# Altium schematic sheet Core.SchDoc(Core)
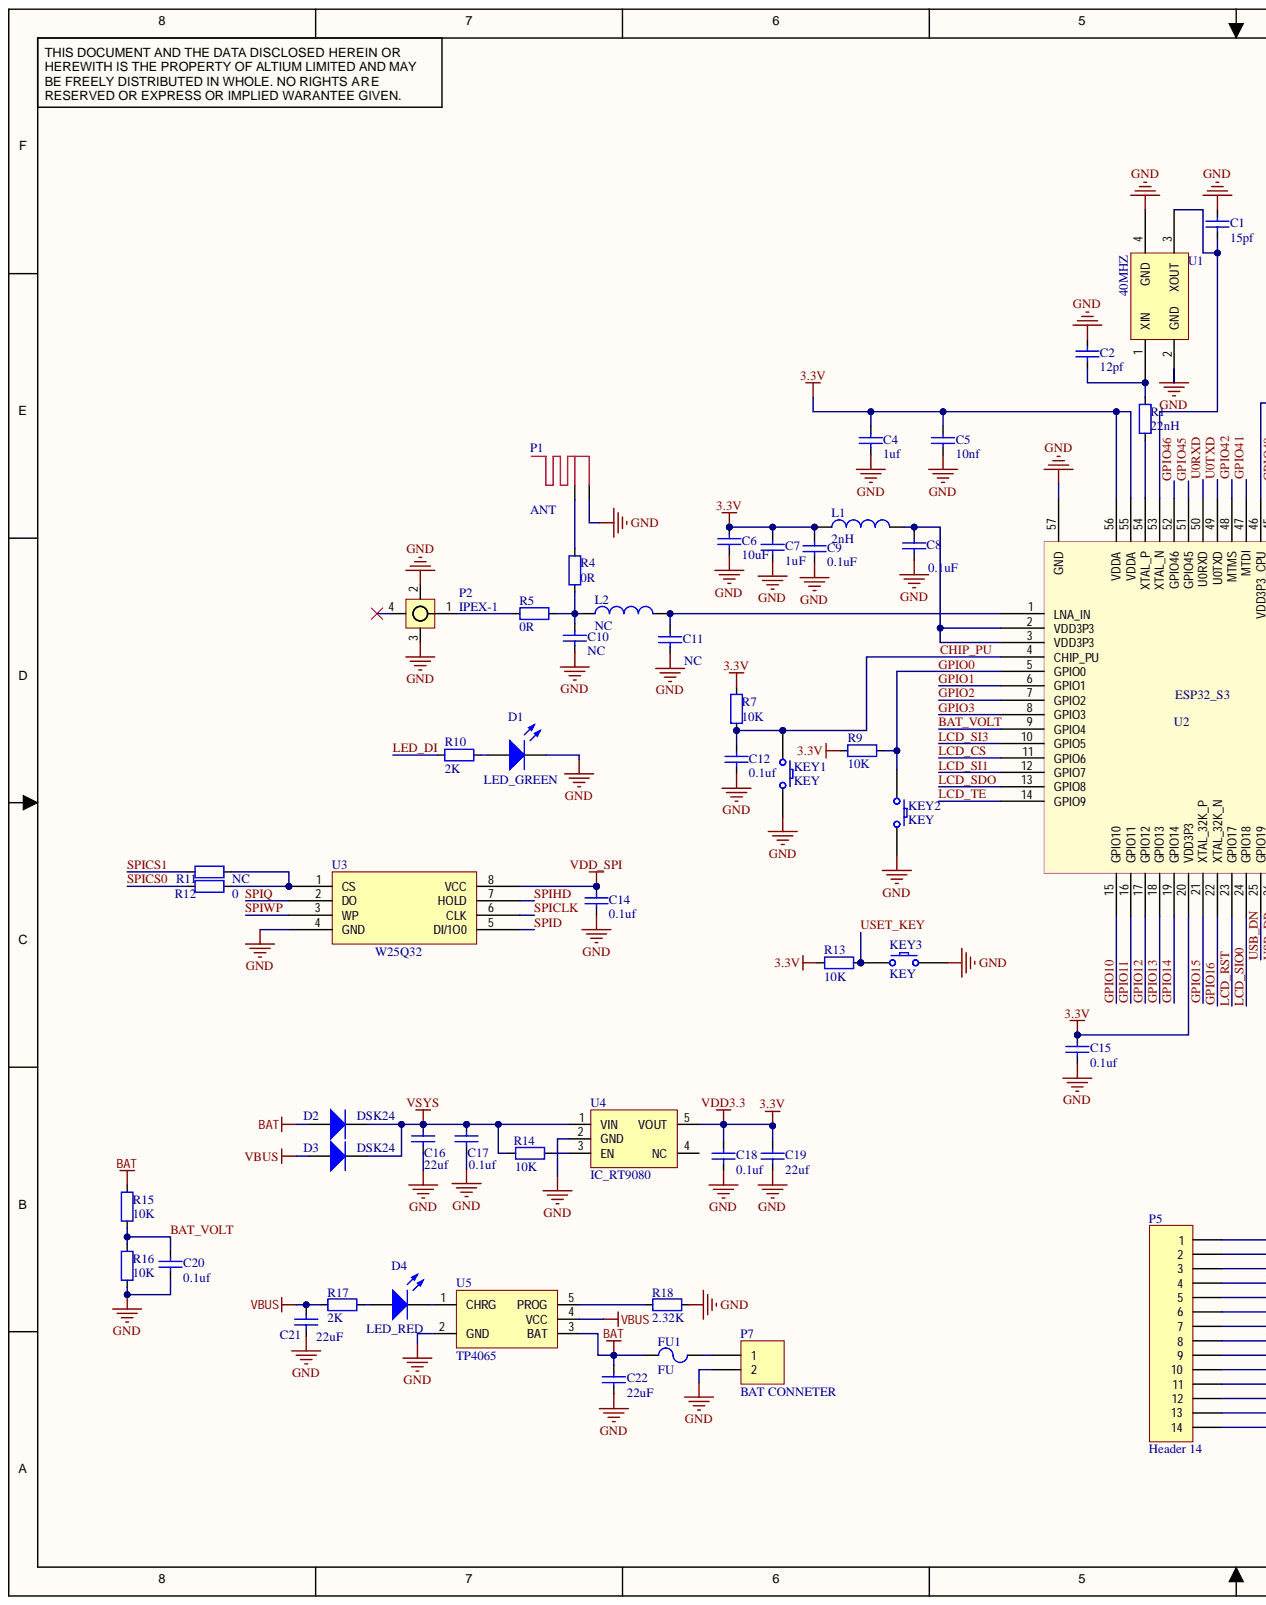
[diagram p0: Core.SchDoc, left]
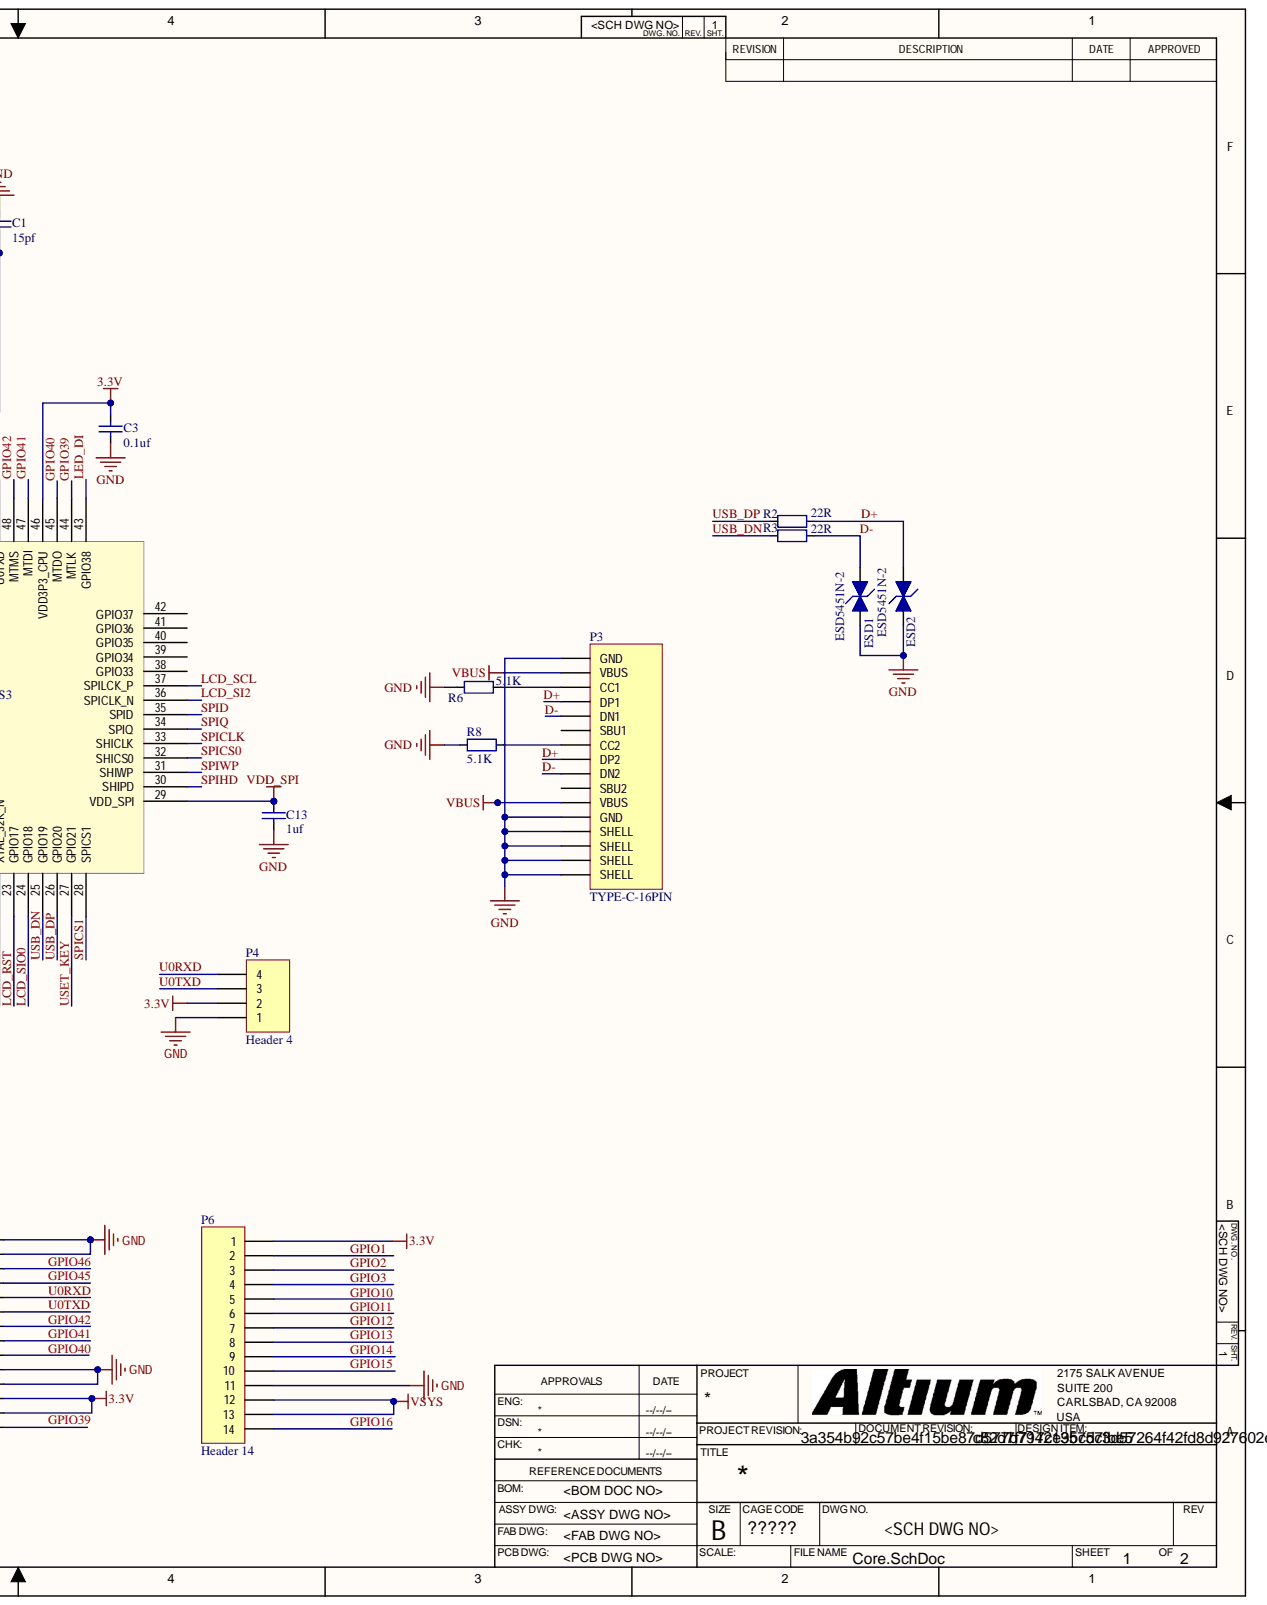
[diagram p1: Core.SchDoc, right]

## Parts
C1  15pf  [CAP_0402]
C2  12pf  [CAP_0402]
C3  0.1uf  [CAP_0402]
C4  1uf  [CAP_0402]
C5  10nf  [CAP_0402]
C6  10uF  [CAP_0603]
C7  1uF  [CAP_0402]
C8  0.1uF  [CAP_0402]
C9  0.1uF  [CAP_0402]
C10  NC  [CAP_0402]
C11  NC  [CAP_0402]
C12  0.1uf  [CAP_0402]
C13  1uf  [CAP_0402]
C14  0.1uf  [CAP_0402]
C15  0.1uf  [CAP_0402]
C16  22uf  [CAP_0603]
C17  0.1uf  [CAP_0402]
C18  0.1uf  [CAP_0402]
C19  22uf  [CAP_0603]
C20  0.1uf  [CAP_0402]
C21  22uF  [CAP_0603]
C22  22uF  [CAP_0603]
D1  LED_GREEN  [LED_0603]
D2  DSK24  [SOD-123FL]
D3  DSK24  [SOD-123FL]
D4  LED_RED  [LED_0603]
ESD1  ESD5451N-2  [ESD]
ESD2  ESD5451N-2  [ESD]
FU1  FU  [FU_0805]
KEY1  KEY  [KEY_EDGE]
KEY2  KEY  [KEY_1]
KEY3  KEY  [KEY_1]
L1  2nH  [RES_0402]
L2  NC  [RES_0402]
P1  ANT  [ANT-2.4G-3D]
P2  IPEX-1  [ANT_IPEX_1]
P3  TYPE-C-16PIN  [USB4105-A]
P4  Header 4  [H-Header_4P-1.0mm]
P5  Header 14  [14P_HDR]
P6  Header 14  [14P_HDR]
P7  BAT CONNETER  [PH_2P_1.25mm]
R1  22nH  [RES_0402]
R2  22R  [RES_0402]
R3  22R  [RES_0402]
R4  0R  [RES_0402]
R5  0R  [RES_0402]
R6  5.1K  [RES_0402]
R7  10K  [RES_0402]
R8  5.1K  [RES_0402]
R9  10K  [RES_0402]
R10  2K  [RES_0402]
R11  NC  [RES_0402]
R12  0  [RES_0402]
R13  10K  [RES_0402]
R14  10K  [RES_0402]
R15  10K  [RES_0402]
R16  10K  [RES_0402]
R17  2K  [RES_0402]
R18  2.32K  [RES_0402]
U1  40MHZ  [SMD_2016]
U2  ESP32_S3  [QFN-56-7x7]
U3  W25Q32  [SOP2-8]
U4  IC_RT9080  [SOT-23-5]
U5  TP4065  [SOT-23-5]

## Nets
3.3V: C3.2 C4.2 C5.2 C6.2 C7.2 C9.2 C15.2 C18.2 C19.2 L1.1 P4.2 P5.12 P5.13 P6.1 R7.2 R9.2 R13.2 U2.20(VDD3P3) U2.46(VDD3P3_CPU) U2.55(VDDA) U2.56(VDDA) U4.5(VOUT)
BAT: C22.2 D2.1 FU1.2 R15.1 U5.3(BAT)
GND: C1.2 C2.2 C3.1 C4.1 C5.1 C6.1 C7.1 C8.1 C9.1 C10.1 C11.1 C12.1 C13.1 C14.1 C15.1 C16.1 C17.1 C18.1 C19.1 C20.1 C21.1 C22.1 D1.2 ESD1.1 ESD2.1 KEY1.2 KEY2.2 KEY3.2 P1.2 P2.2 P2.3 P3.A1_B12(GND) P3.B1_A12(GND) P3.S1(SHELL) P3.S2(SHELL) P3.S3(SHELL) P3.S4(SHELL) P4.1 P5.1 P5.2 P5.10 P5.11 P6.11 P7.2 R6.2 R8.1 R16.2 R18.2 U1.2(GND) U1.4(GND) U2.57(GND) U3.4(GND) U4.2(GND) U5.2(GND)
VBUS: C21.2 D3.1 P3.A4_B9(VBUS) P3.B4_A9(VBUS) R17.1 U5.4(VCC)
VDD_SPI: C13.2 C14.2 U2.29(VDD_SPI) U3.8(VCC)
VSYS: C16.2 C17.2 D2.2 D3.2 P6.12 P6.13 R14.1 U4.1(VIN)
BAT_VOLT: C20.2 R15.2 R16.1 U2.9(GPIO4)
CHIP_PU: C12.2 KEY1.1 R7.1 U2.4(CHIP_PU)
D+: ESD2.2 P3.A6(DP1) P3.B6(DP2) R2.1
D-: ESD1.2 P3.A7(DN1) P3.B7(DN2) R3.1
GPIO0: KEY2.1 R9.1 U2.5(GPIO0)
GPIO1: P6.2 U2.6(GPIO1)
GPIO2: P6.3 U2.7(GPIO2)
GPIO3: P6.4 U2.8(GPIO3)
GPIO10: P6.5 U2.15(GPIO10)
GPIO11: P6.6 U2.16(GPIO11)
GPIO12: P6.7 U2.17(GPIO12)
GPIO13: P6.8 U2.18(GPIO13)
GPIO14: P6.9 U2.19(GPIO14)
GPIO15: P6.10 U2.21(XTAL_32K_P)
GPIO16: P6.14 U2.22(XTAL_32K_N)
GPIO39: P5.14 U2.44(MTLK)
GPIO40: P5.9 U2.45(MTDO)
GPIO41: P5.8 U2.47(MTDI)
GPIO42: P5.7 U2.48(MTMS)
GPIO45: P5.4 U2.51(GPIO45)
GPIO46: P5.3 U2.52(GPIO46)
LCD_CS: U2.11(GPIO6)
LCD_RST: U2.23(GPIO17)
LCD_SCL: U2.37(SPILCK_P)
LCD_SDO: U2.13(GPIO8)
LCD_SI1: U2.12(GPIO7)
LCD_SI2: U2.36(SPICLK_N)
LCD_SI3: U2.10(GPIO5)
LCD_SIO0: U2.24(GPIO18)
LCD_TE: U2.14(GPIO9)
LED_DI: R10.1 U2.43(GPIO38)
SPICLK: U2.33(SHICLK) U3.6(CLK)
SPICS0: R12.2 U2.32(SHICS0)
SPICS1: R11.2 U2.28(SPICS1)
SPID: U2.35(SPID) U3.5(DI/1O0)
SPIHD: U2.30(SHIPD) U3.7(HOLD)
SPIQ: U2.34(SPIQ) U3.2(DO)
SPIWP: U2.31(SHIWP) U3.3(WP)
U0RXD: P4.4 P5.5 U2.50(U0RXD)
U0TXD: P4.3 P5.6 U2.49(U0TXD)
USB_DN: R3.2 U2.25(GPIO19)
USB_DP: R2.2 U2.26(GPIO20)
USET_KEY: KEY3.1 R13.1 U2.27(GPIO21)
NetC1_1: C1.1 U1.3(XOUT) U2.53(XTAL_N)
NetC2_1: C2.1 R1.2 U1.1(XIN)
NetC8_2: C8.2 L1.2 U2.2(VDD3P3) U2.3(VDD3P3)
NetC10_2: C10.2 L2.1 R4.1 R5.1
NetC11_2: C11.2 L2.2 U2.1(LNA_IN)
NetD1_1: D1.1 R10.2
NetD4_1: D4.1 R17.2
NetD4_2: D4.2 U5.1(CHRG)
NetFU1_1: FU1.1 P7.1
NetP1_1: P1.1 R4.2
NetP2_1: P2.1 R5.2
NetP3_A5: P3.A5(CC1) R6.1
NetP3_B5: P3.B5(CC2) R8.2
NetR1_1: R1.1 U2.54(XTAL_P)
NetR11_1: R11.1 R12.1 U3.1(CS)
NetR14_2: R14.2 U4.3(EN)
NetR18_1: R18.1 U5.5(PROG)

Not connected: P2 4; P3 A8(SBU1) B8(SBU2); U2 38(GPIO33) 39(GPIO34) 40(GPIO35) 41(GPIO36) 42(GPIO37); U4 4(NC)

## Library data of the parts
C1-C22 = A_Capacitor_1: Ceramics Capacitor; Component Kind: Standard; Library Name: SCH_Lib.SchLib; Signal Integrity: CAPACITOR; Simulation: CAPACITOR
D1 D4 = C_LED_1: Component Kind: Standard; Library Name: SCH_Lib.SchLib
D2 D3 = B_Diode_1: Normal Diode; Component Kind: Standard; Library Name: SCH_Lib.SchLib
ESD1 ESD2 = ESD: Component Kind: Standard; Library Name: Micky.SchLib
FU1 = B_FU: Adjust Resistor; Component Kind: Standard; Library Name: SCH_Lib.SchLib; Signal Integrity: RESISTOR; Simulation: RESISTOR
KEY1-KEY3 = C_SW_KEY_1: Component Kind: Standard; Library Name: SCH_Lib.SchLib
L1 L2 = Inductor: Code_IEC: 1005; Value: 0H; Code_JEITA: 0402; Component Kind: Standard; Description: Inductor; LatestRevisionDate: 29-May-2009; LatestRevisionNote: IPC-7351 Footprint Added.; Library Name: Miscellaneous Devices.IntLib; PackageDescription: Chip Inductor; PackageReference: 0402-A; Published: 8-Jun-2000; Signal Integrity: Inductor; Simulation: INDUCTOR; Publisher: Altium Limited
P4 = H_Header_4: Component Kind: Standard; Library Name: SCH_Lib.SchLib
P5 P6 = H_Header_14: Component Kind: Standard; Library Name: SCH_Lib.SchLib
P7 = H_Header_2: Component Kind: Standard; Library Name: SCH_Lib.SchLib
R1-R18 = A_Resistor_1: Normal Resistor; Component Kind: Standard; Library Name: SCH_Lib.SchLib; Signal Integrity: RESISTOR; Simulation: RESISTOR
U1 = CRY-D3225: Component Kind: Standard; Library Name: 885阿里云PCB_Project1.SCHLIB
U3 = GD25LQ128DSIGR: Component Kind: Standard; Library Name: 885阿里云PCB_Project1.SCHLIB
P1 = ANT: Component Kind: Standard; Library Name: Micky.SchLib
P2 = IPEX-1: Component Kind: Standard; Library Name: Micky.SchLib
P3 = TYPE-C-16PIN: Component Kind: Standard; Library Name: Micky.SchLib
U2 = ESP32_S3: Component Kind: Standard; Library Name: 885阿里云PCB_Project1.SCHLIB
U4 = IC_RT9080: Component Kind: Standard; Library Name: Micky.SchLib
U5 = TP4065: Component Kind: Standard; Library Name: Micky.SchLib
